# Altium schematic sheet HLib-Comm-BLE_r1.SchDoc
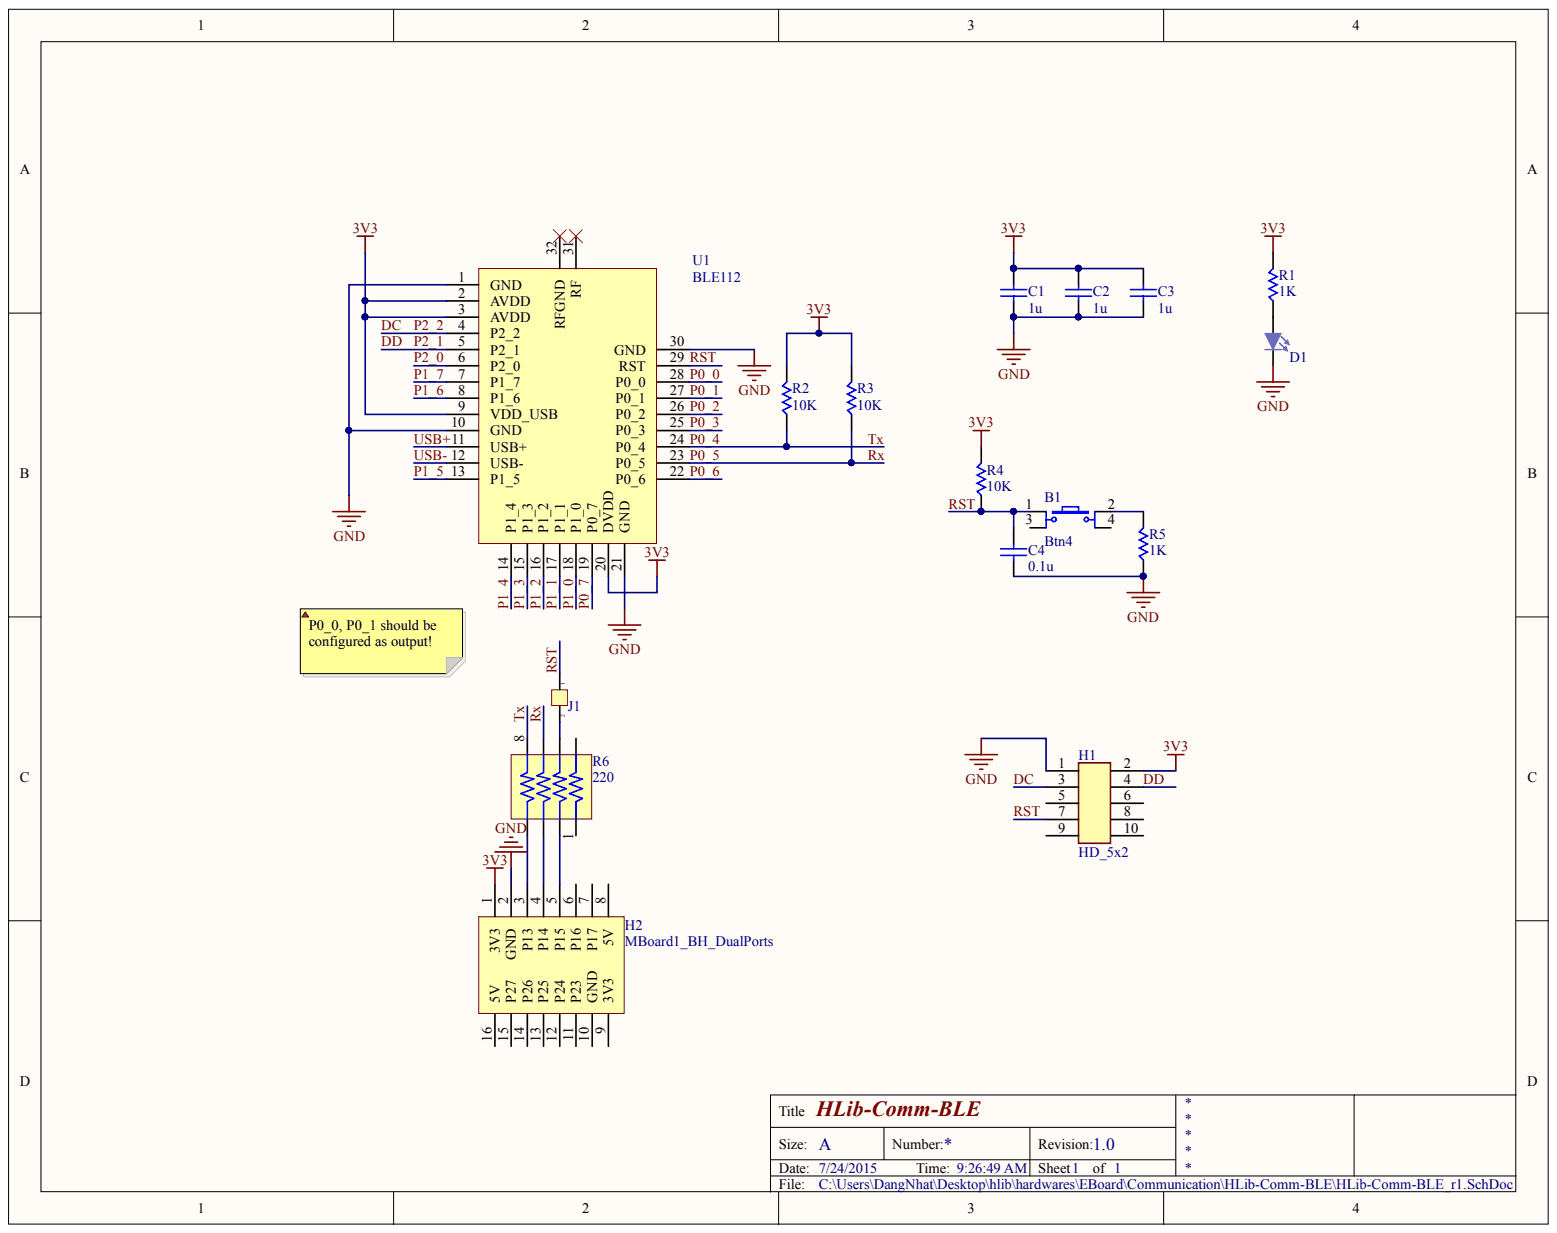
[diagram p0: HLib-Comm-BLE_r1.SchDoc]

## Parts
B1  Btn4  [Btn-smd4]
C1  value 1u  [SM_0805_C_CERAMIC]  (hidden comment: C_Ceramic)
C2  value 1u  [SM_0805_C_CERAMIC]  (hidden comment: C_Ceramic)
C3  value 1u  [SM_0805_C_CERAMIC]  (hidden comment: C_Ceramic)
C4  value 0.1u  [SM_0805_C_CERAMIC]  (hidden comment: C_Ceramic)
D1  LED  [SM_0805_LED]  (value not drawn)
H1  HD_5x2  [HD_male_5x2_100mil_straight]
H2  MBoard1_BH_DualPorts  [MBoard1_BH_DualPorts]
J1  Jumper 2  [J2]  (value not drawn)
R1  R  value 1K  [SM_0805_R]
R2  R  value 10K  [SM_0805_R]
R3  R  value 10K  [SM_0805_R]
R4  R  value 10K  [SM_0805_R]
R5  R  value 1K  [SM_0805_R]
R6  220  [RA4]
U1  BLE112  [BLE112]

## Nets
3V3: C1.2 C2.2 C3.2 H1.2 H2.1(3V3) R1.2 R2.2 R3.2 R4.2 U1.2(AVDD) U1.3(AVDD) U1.9(VDD_USB) U1.20(DVDD)
GND: C1.1 C2.1 C3.1 C4.1 D1.2 H1.1 H2.2(GND) R5.1 U1.1(GND) U1.10(GND) U1.21(GND) U1.30(GND)
DC: H1.3 U1.4(P2_2)
DD: H1.4 U1.5(P2_1)
P0_0: U1.28(P0_0)
P0_1: U1.27(P0_1)
P0_2: U1.26(P0_2)
P0_3: U1.25(P0_3)
P0_4: R2.1 R6.8 U1.24(P0_4)
P0_5: R3.1 R6.6 U1.23(P0_5)
P0_6: U1.22(P0_6)
P0_7: U1.19(P0_7)
P1_0: U1.18(P1_0)
P1_1: U1.17(P1_1)
P1_2: U1.16(P1_2)
P1_3: U1.15(P1_3)
P1_4: U1.14(P1_4)
P1_5: U1.13(P1_5)
P1_6: U1.8(P1_6)
P1_7: U1.7(P1_7)
P2_0: U1.6(P2_0)
RST: B1.1 C4.2 H1.7 J1.1 R4.1 U1.29(RST)
USB+: U1.11(USB+)
USB-: U1.12(USB-)
NetB1_2: B1.2 R5.2
NetD1_1: D1.1 R1.1
NetH2_3: H2.3(P13) R6.7
NetH2_4: H2.4(P14) R6.5
NetH2_5: H2.5(P15) R6.3
NetJ1_2: J1.2 R6.4

Not connected: B1 3 4; H1 5 6 8 9 10; H2 6(P16) 7(P17) 8(5V) 9(3V3) 10(GND) 11(P23) 12(P24) 13(P25) 14(P26) 15(P27) 16(5V); R6 1 2; U1 31(RF) 32(RFGND)

## Library data of the parts
C1-C4 = C_Ceramic: Non-polarity ceramic capacitor; Component Kind: Standard; LatestRevisionDate: 17-Jul-2002; LatestRevisionNote: Re-released for DXP Platform.; Library Name: HLib_discrete_sch.SchLib; PackageDescription: Radial Cap, Thru-Hole; 2 Leads; 0.3 in Pin Spacing; PackageReference: RAD-0.3; Published: 8-Jun-2000; Publisher: Altium Limited
H1 = HD_5x2: Header, 5-pins/row, double row,; Component Kind: Standard; Library Name: HLib_connector_sch.SchLib
J1 = JP 2: Value: JP 2; Component Kind: Standard; Description: Jumper 2; Library Name: VioletofSun.SchLib
R1-R5 = R: Resistor; Component Kind: Standard; LatestRevisionDate: 29-May-2009; LatestRevisionNote: IPC-7351 Footprint Added.; Library Name: HLib_discrete_sch.SchLib; PackageDescription: Chip Resistor; PackageReference: J1-0603; Published: 8-Jun-2000; Publisher: Altium Limited
R6 = RA4: Component Kind: Standard; Library Name: Nhat_Lib.SchLib
B1 = Btn4: Component Kind: Standard; Library Name: Nhat_Lib.SchLib
D1 = LED: Component Kind: Standard; Description: LED; LatestRevisionDate: 08-Jul-2005; LatestRevisionNote: Mech Layer 1 removed.; Library Name: HLib_discrete_sch.SchLib; PackageDescription: LED; 2 Leads; PackageReference: LED-1; Published: 8-Jun-2000; Publisher: Altium Limited
H2 = MBoard1_BH_DualPorts: Component Kind: Standard; Library Name: Nhat_Lib.SchLib
U1 = BLE112: Component Kind: Standard; Library Name: Nhat_Lib.SchLib
